# Altium schematic sheet AD936X_RF.SchDoc(AD936X_RF)
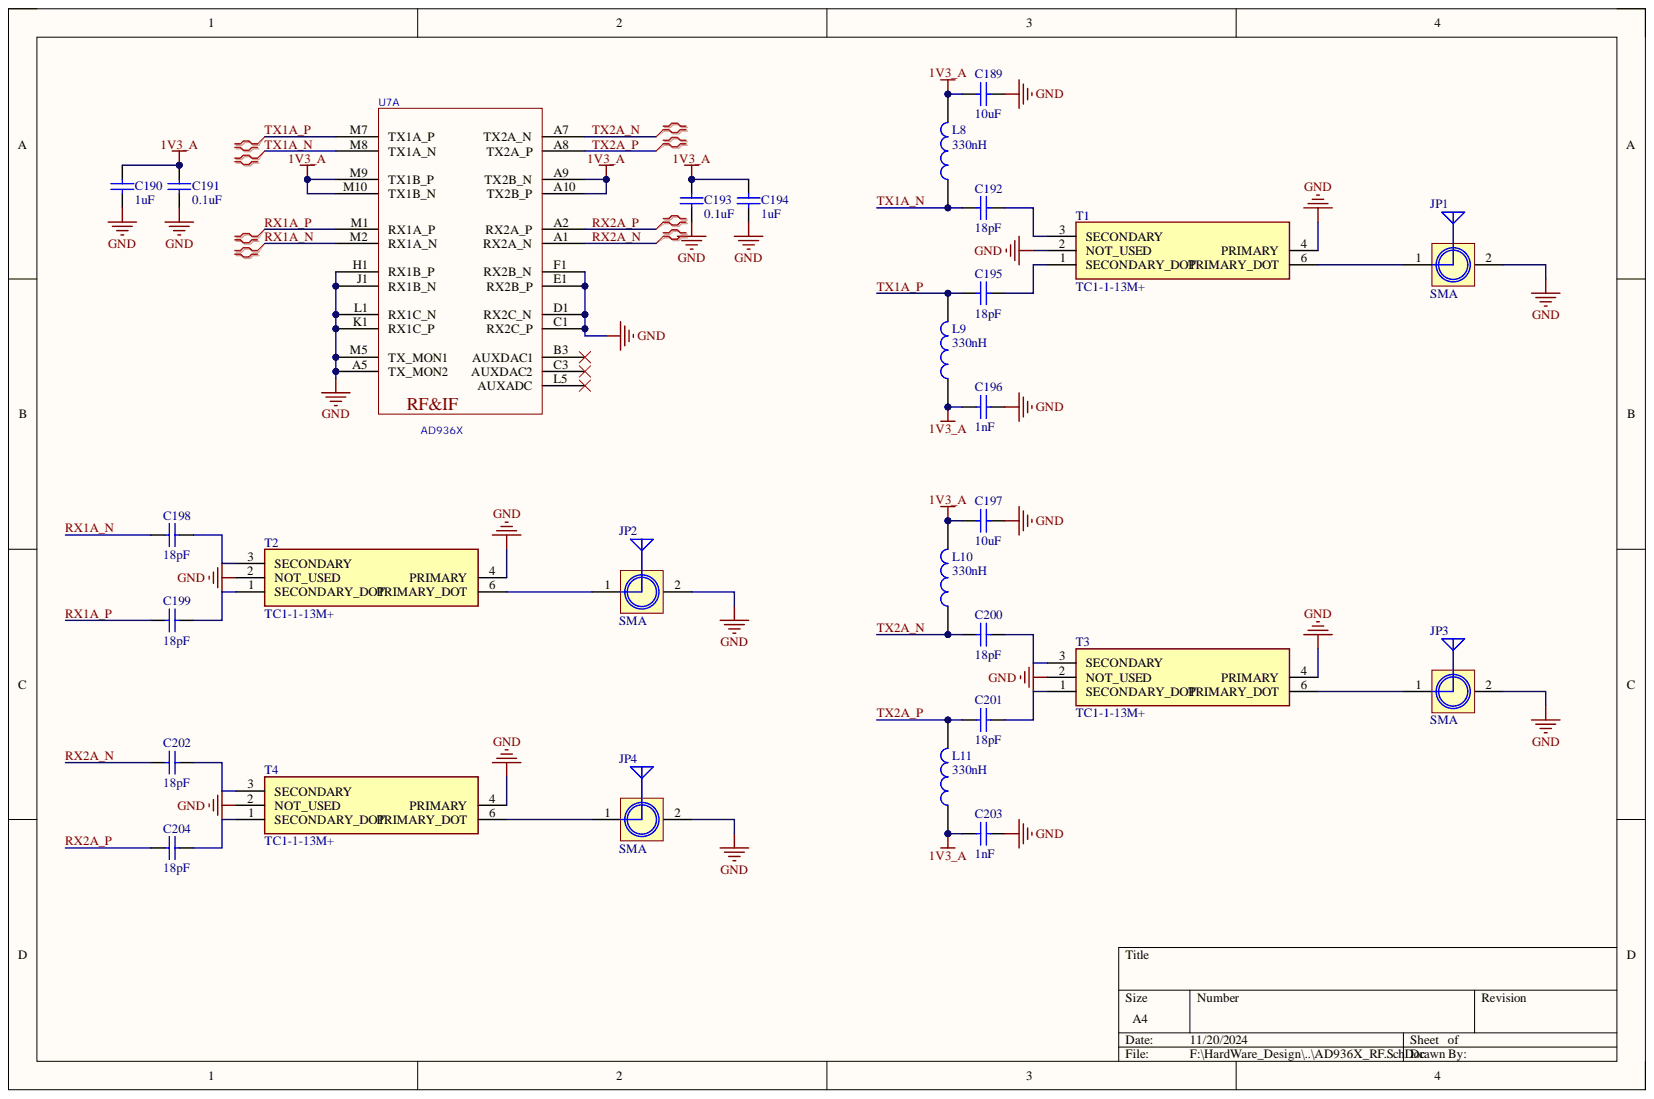
[diagram p0: AD936X_RF.SchDoc]

## Parts
C189  10uF  [C 0603_L]
C190  1uF  [C 0402_L]
C191  0.1uF  [C 0402_L]
C192  18pF  [C 0402_L]
C193  0.1uF  [C 0402_L]
C194  1uF  [C 0402_L]
C195  18pF  [C 0402_L]
C196  1nF  [C 0402_L]
C197  10uF  [C 0603_L]
C198  18pF  [C 0402_L]
C199  18pF  [C 0402_L]
C200  18pF  [C 0402_L]
C201  18pF  [C 0402_L]
C202  18pF  [C 0402_L]
C203  1nF  [C 0402_L]
C204  18pF  [C 0402_L]
JP1  SMA  [SMA-KE]
JP2  SMA  [SMA-KE]
JP3  SMA  [SMA-KE]
JP4  SMA  [SMA-KE]
L8  330nH  [L 0603]
L9  330nH  [L 0603]
L10  330nH  [L 0603]
L11  330nH  [L 0603]
T1  TC1-1-13M+  [TCM163AX2]
T2  TC1-1-13M+  [TCM163AX2]
T3  TC1-1-13M+  [TCM163AX2]
T4  TC1-1-13M+  [TCM163AX2]
U7  AD936X  [BGA144C80P12X12_1000X1000X170]  (part A)

## Nets
1V3_A: C189.1 C190.2 C191.2 C193.2 C194.2 C196.2 C197.1 C203.2 L8.2 L9.1 L10.2 L11.1 U7.A9(TX2B_N) U7.A10(TX2B_P) U7.M9(TX1B_P) U7.M10(TX1B_N)
GND: C189.2 C190.1 C191.1 C193.1 C194.1 C196.1 C197.2 C203.1 JP1.2 JP2.2 JP3.2 JP4.2 T1.2(NOT_USED) T1.4(PRIMARY) T2.2(NOT_USED) T2.4(PRIMARY) T3.2(NOT_USED) T3.4(PRIMARY) T4.2(NOT_USED) T4.4(PRIMARY) U7.A5(TX_MON2) U7.C1(RX2C_P) U7.D1(RX2C_N) U7.E1(RX2B_P) U7.F1(RX2B_N) U7.H1(RX1B_P) U7.J1(RX1B_N) U7.K1(RX1C_P) U7.L1(RX1C_N) U7.M5(TX_MON1)
RX1A_N: C198.1 U7.M2(RX1A_N)
RX1A_P: C199.1 U7.M1(RX1A_P)
RX2A_N: C202.1 U7.A1(RX2A_N)
RX2A_P: C204.1 U7.A2(RX2A_P)
TX1A_N: C192.1 L8.1 U7.M8(TX1A_N)
TX1A_P: C195.1 L9.2 U7.M7(TX1A_P)
TX2A_N: C200.1 L10.1 U7.A7(TX2A_N)
TX2A_P: C201.1 L11.2 U7.A8(TX2A_P)
NetC192_2: C192.2 T1.3(SECONDARY)
NetC195_2: C195.2 T1.1(SECONDARY_DOT)
NetC198_2: C198.2 T2.3(SECONDARY)
NetC199_2: C199.2 T2.1(SECONDARY_DOT)
NetC200_2: C200.2 T3.3(SECONDARY)
NetC201_2: C201.2 T3.1(SECONDARY_DOT)
NetC202_2: C202.2 T4.3(SECONDARY)
NetC204_2: C204.2 T4.1(SECONDARY_DOT)
NetJP1_1: JP1.1 T1.6(PRIMARY_DOT)
NetJP2_1: JP2.1 T2.6(PRIMARY_DOT)
NetJP3_1: JP3.1 T3.6(PRIMARY_DOT)
NetJP4_1: JP4.1 T4.6(PRIMARY_DOT)

Not connected: U7 B3(AUXDAC1) C3(AUXDAC2) L5(AUXADC)

## Library data of the parts
C189-C204 = Cap: 贴片电容; Component Kind: Standard; Library Name: A_Schlib.SchLib
  C189 C197: value: 10uF
  C190 C194: value: 1uF
  C191 C193: value: 0.1uF
  C192 C195 C198-C202 C204: value: 18pF
  C196 C203: value: 1nF
JP1-JP4 = SMA: SMA-2.4G射频天线接口; 版权归属: 源创客; BOM名称: SMA-2.4G射频天线接口; Component Kind: Standard; Library Name: A_Schlib.SchLib; 学习资源: www.ooocke.com
L8-L11 = 电感: 包含功率; Component Kind: Standard; Library Name: A_Schlib.SchLib
T1-T4 = TC1-1-13M+: Transformer; Manufacturer_Name: Mini-Circuits; Component Kind: Standard; Datasheet Link: https://datasheet.datasheetarchive.com/originals/distributors/Datasheets-28/DSA-546322.pdf; Height: 4.06mm; Library Name: SamacSys.SchLib; Manufacturer_Part_Number: TC1-1-13M+; Mouser Part Number: 139-TC1-1-13M; Mouser Price/Stock: https://www.mouser.co.uk/ProductDetail/Mini-Circuits/TC1-1-13M%2b?qs=xZ%2FP%252Ba9zWqYek%252BZGAF2fWg%3D%3D
U7 = AD936X: Component Kind: Standard; Library Name: A_Schlib.SchLib
